# Altium schematic sheet DC_Motor_Drivers.SchDoc(DC_Motor_Drivers)
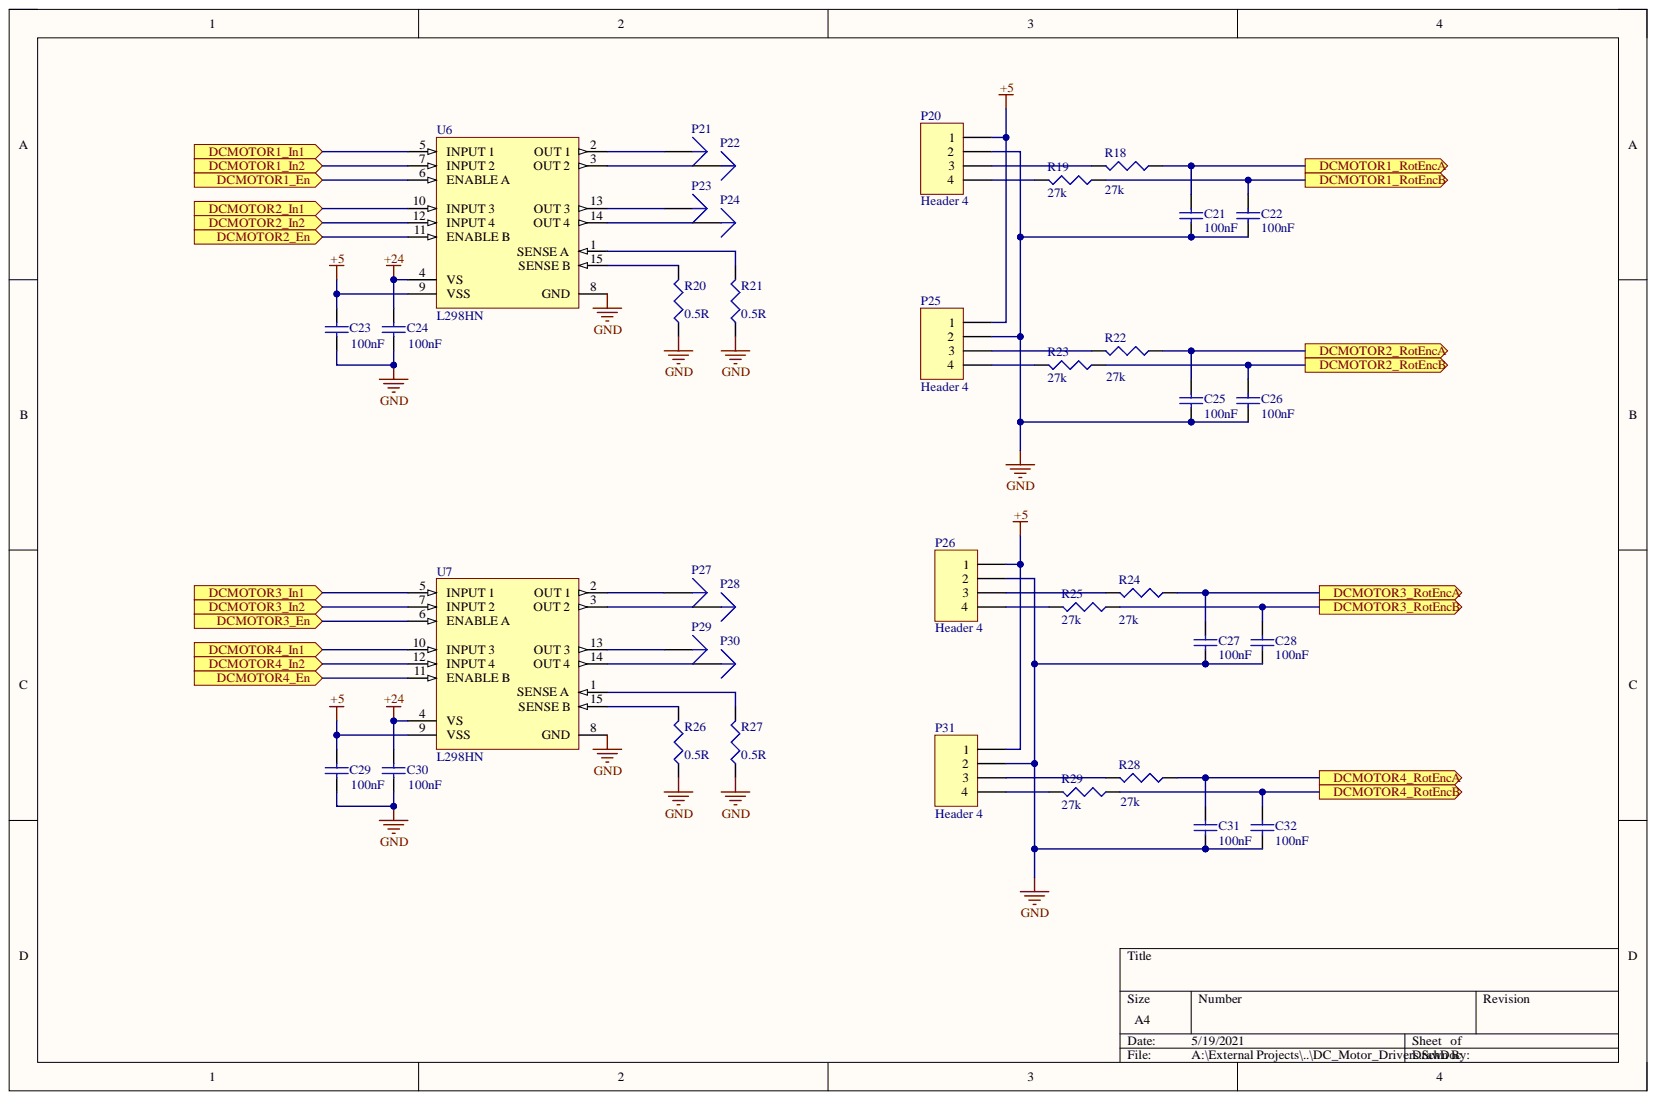
[diagram p0: DC_Motor_Drivers.SchDoc]

## Parts
C21  value 100nF  [C1206]  (hidden comment: Cap Semi)
C22  value 100nF  [C1206]  (hidden comment: Cap Semi)
C23  value 100nF  [C1206]  (hidden comment: Cap Semi)
C24  value 100nF  [C1206]  (hidden comment: Cap Semi)
C25  value 100nF  [C1206]  (hidden comment: Cap Semi)
C26  value 100nF  [C1206]  (hidden comment: Cap Semi)
C27  value 100nF  [C1206]  (hidden comment: Cap Semi)
C28  value 100nF  [C1206]  (hidden comment: Cap Semi)
C29  value 100nF  [C1206]  (hidden comment: Cap Semi)
C30  value 100nF  [C1206]  (hidden comment: Cap Semi)
C31  value 100nF  [C1206]  (hidden comment: Cap Semi)
C32  value 100nF  [C1206]  (hidden comment: Cap Semi)
P20  Header 4  [HDR1X4]
P21  Plug  [PIN1]  (value not drawn)
P22  Plug  [PIN1]  (value not drawn)
P23  Plug  [PIN1]  (value not drawn)
P24  Plug  [PIN1]  (value not drawn)
P25  Header 4  [HDR1X4]
P26  Header 4  [HDR1X4]
P27  Plug  [PIN1]  (value not drawn)
P28  Plug  [PIN1]  (value not drawn)
P29  Plug  [PIN1]  (value not drawn)
P30  Plug  [PIN1]  (value not drawn)
P31  Header 4  [HDR1X4]
R18  value 27k  [J1-0603]  (hidden comment: Res3)
R19  value 27k  [J1-0603]  (hidden comment: Res3)
R20  value 0.5R  [RESC6332]  (hidden comment: Res3)
R21  value 0.5R  [RESC6332]  (hidden comment: Res3)
R22  value 27k  [J1-0603]  (hidden comment: Res3)
R23  value 27k  [J1-0603]  (hidden comment: Res3)
R24  value 27k  [J1-0603]  (hidden comment: Res3)
R25  value 27k  [J1-0603]  (hidden comment: Res3)
R26  value 0.5R  [RESC6332]  (hidden comment: Res3)
R27  value 0.5R  [RESC6332]  (hidden comment: Res3)
R28  value 27k  [J1-0603]  (hidden comment: Res3)
R29  value 27k  [J1-0603]  (hidden comment: Res3)
U6  L298HN  [STM-MULTIWATT15V-15]
U7  L298HN  [STM-MULTIWATT15V-15]

## Ports
DCMOTOR1_En, DCMOTOR1_In1, DCMOTOR1_In2, DCMOTOR1_RotEncA, DCMOTOR1_RotEncB, DCMOTOR2_En, DCMOTOR2_In1, DCMOTOR2_In2, DCMOTOR2_RotEncA, DCMOTOR2_RotEncB, DCMOTOR3_En, DCMOTOR3_In1, DCMOTOR3_In2, DCMOTOR3_RotEncA, DCMOTOR3_RotEncB, DCMOTOR4_En, DCMOTOR4_In1, DCMOTOR4_In2, DCMOTOR4_RotEncA, DCMOTOR4_RotEncB

## Nets
+5: C23.2 C29.2 P20.1 P25.1 P26.1 P31.1 U6.9(VSS) U7.9(VSS)
+24: C24.2 C30.2 U6.4(VS) U7.4(VS)
GND: C21.1 C22.1 C23.1 C24.1 C25.1 C26.1 C27.1 C28.1 C29.1 C30.1 C31.1 C32.1 P20.2 P25.2 P26.2 P31.2 R20.1 R21.1 R26.1 R27.1 U6.8(GND) U7.8(GND)
DCMOTOR1_En: U6.6(ENABLE_A) ; port DCMOTOR1_En
DCMOTOR1_In1: U6.5(INPUT_1) ; port DCMOTOR1_In1
DCMOTOR1_In2: U6.7(INPUT_2) ; port DCMOTOR1_In2
DCMOTOR1_RotEncA: C21.2 R18.2 ; port DCMOTOR1_RotEncA
DCMOTOR1_RotEncB: C22.2 R19.2 ; port DCMOTOR1_RotEncB
DCMOTOR2_En: U6.11(ENABLE_B) ; port DCMOTOR2_En
DCMOTOR2_In1: U6.10(INPUT_3) ; port DCMOTOR2_In1
DCMOTOR2_In2: U6.12(INPUT_4) ; port DCMOTOR2_In2
DCMOTOR2_RotEncA: C25.2 R22.2 ; port DCMOTOR2_RotEncA
DCMOTOR2_RotEncB: C26.2 R23.2 ; port DCMOTOR2_RotEncB
DCMOTOR3_En: U7.6(ENABLE_A) ; port DCMOTOR3_En
DCMOTOR3_In1: U7.5(INPUT_1) ; port DCMOTOR3_In1
DCMOTOR3_In2: U7.7(INPUT_2) ; port DCMOTOR3_In2
DCMOTOR3_RotEncA: C27.2 R24.2 ; port DCMOTOR3_RotEncA
DCMOTOR3_RotEncB: C28.2 R25.2 ; port DCMOTOR3_RotEncB
DCMOTOR4_En: U7.11(ENABLE_B) ; port DCMOTOR4_En
DCMOTOR4_In1: U7.10(INPUT_3) ; port DCMOTOR4_In1
DCMOTOR4_In2: U7.12(INPUT_4) ; port DCMOTOR4_In2
DCMOTOR4_RotEncA: C31.2 R28.2 ; port DCMOTOR4_RotEncA
DCMOTOR4_RotEncB: C32.2 R29.2 ; port DCMOTOR4_RotEncB
NetP20_3: P20.3 R18.1
NetP20_4: P20.4 R19.1
NetP21_1: P21.1 U6.2(OUT_1)
NetP22_1: P22.1 U6.3(OUT_2)
NetP23_1: P23.1 U6.13(OUT_3)
NetP24_1: P24.1 U6.14(OUT_4)
NetP25_3: P25.3 R22.1
NetP25_4: P25.4 R23.1
NetP26_3: P26.3 R24.1
NetP26_4: P26.4 R25.1
NetP27_1: P27.1 U7.2(OUT_1)
NetP28_1: P28.1 U7.3(OUT_2)
NetP29_1: P29.1 U7.13(OUT_3)
NetP30_1: P30.1 U7.14(OUT_4)
NetP31_3: P31.3 R28.1
NetP31_4: P31.4 R29.1
NetR20_2: R20.2 U6.15(SENSE_B)
NetR21_2: R21.2 U6.1(SENSE_A)
NetR26_2: R26.2 U7.15(SENSE_B)
NetR27_2: R27.2 U7.1(SENSE_A)

## Library data of the parts
C21-C32 = Cap Semi: Capacitor (Semiconductor SIM Model); Component Kind: Standard; LatestRevisionDate: 29-May-2009; LatestRevisionNote: IPC-7351 Footprint Added.; Library Name: Miscellaneous Devices.IntLib; PackageDescription: Chip Capacitor; PackageReference: C1206; Published: 8-Jun-2000; Signal Integrity: Cap Semi; Simulation: CAP; Publisher: Altium Limited
P20 P25 P26 P31 = Header 4: Header, 4-Pin; Component Kind: Standard; LatestRevisionDate: 17-Jul-2002; LatestRevisionNote: Re-released for DXP Platform.; Library Name: Miscellaneous Connectors.IntLib; Signal Integrity: Connector; Publisher: Altium Limited
R18 R22 R24 R28 = Res3: Resistor; Value: 27k; Component Kind: Standard; LatestRevisionDate: 29-May-2009; LatestRevisionNote: IPC-7351 Footprint Added.; Library Name: Miscellaneous Devices.IntLib; PackageDescription: Chip Resistor; PackageReference: J1-0603; Published: 8-Jun-2000; Signal Integrity: Res; Simulation: RESISTOR; Publisher: Altium Limited
R19-R21 R23 R25-R27 R29 = Res3: Resistor; Component Kind: Standard; LatestRevisionDate: 29-May-2009; LatestRevisionNote: IPC-7351 Footprint Added.; Library Name: Miscellaneous Devices.IntLib; PackageDescription: Chip Resistor; PackageReference: J1-0603; Published: 8-Jun-2000; Signal Integrity: Res; Simulation: RESISTOR; Publisher: Altium Limited
U6 U7 = CMP-2000-06525-1: Dual Full-Bridge Driver, 4.5 to 7 V, -25 to 130 degC, 15-Pin MULTIWATT15V, RoHS, Tube; Manufacturer: STMicroelectronics; Current - Output / Channel: 2A; Current - Peak Output: 3A; Dynamic Catalog: HBridge; Input Type: Non-Inverting; Number of Outputs: 4; Other Names: 497-2940-5; Other Related Documents: L298ViewAllSpecifications; Product Training Modules: SmallMotorDrivers; Voltage - Supply: 4.5V~46V; Category: IntegratedCircuits(ICs); Component Kind: Standard; Datasheet URL: http://www.st.com/content/ccc/resource/technical/document/datasheet/82/cc/3f/39/0a/29/4d/f0/CD00000240.pdf/files/CD00000240.pdf/jcr:content/translations/en.CD00000240.pdf; Datasheet Version: 01/2000; Family: PMIC-MOSFET,BridgeDrivers-InternalSwitch; Library Name: Altium Content Vault; Manufacturer URL: http://www.st.com/; Mounting Technology: ThroughHole; Mounting Type: ThroughHole; On-State Resistance: -; Operating Temperature: -25°C~130°C; Package / Case: Multiwatt-15(Horizontal,BentandStaggeredLeads); Package Description: 15-Pin Flange Mount, Body 20.2 x 5 mm, Pitch 1.27 mm; Package Reference: MULTIWATT15V-15; Packaging: Tube; Series: -; Standard Package: 25; Supplier Device Package: 15-Multiwatt; Type: H-Bridge
P21-P24 P27-P30 = Plug: Component Kind: Standard; Description: Plug; LatestRevisionDate: 17-Jul-2002; LatestRevisionNote: Re-released for DXP Platform.; Library Name: Miscellaneous Connectors.IntLib; Signal Integrity: Connector; Publisher: Altium Limited
